# Altium schematic sheet Schematic.SchDoc(Schematic)
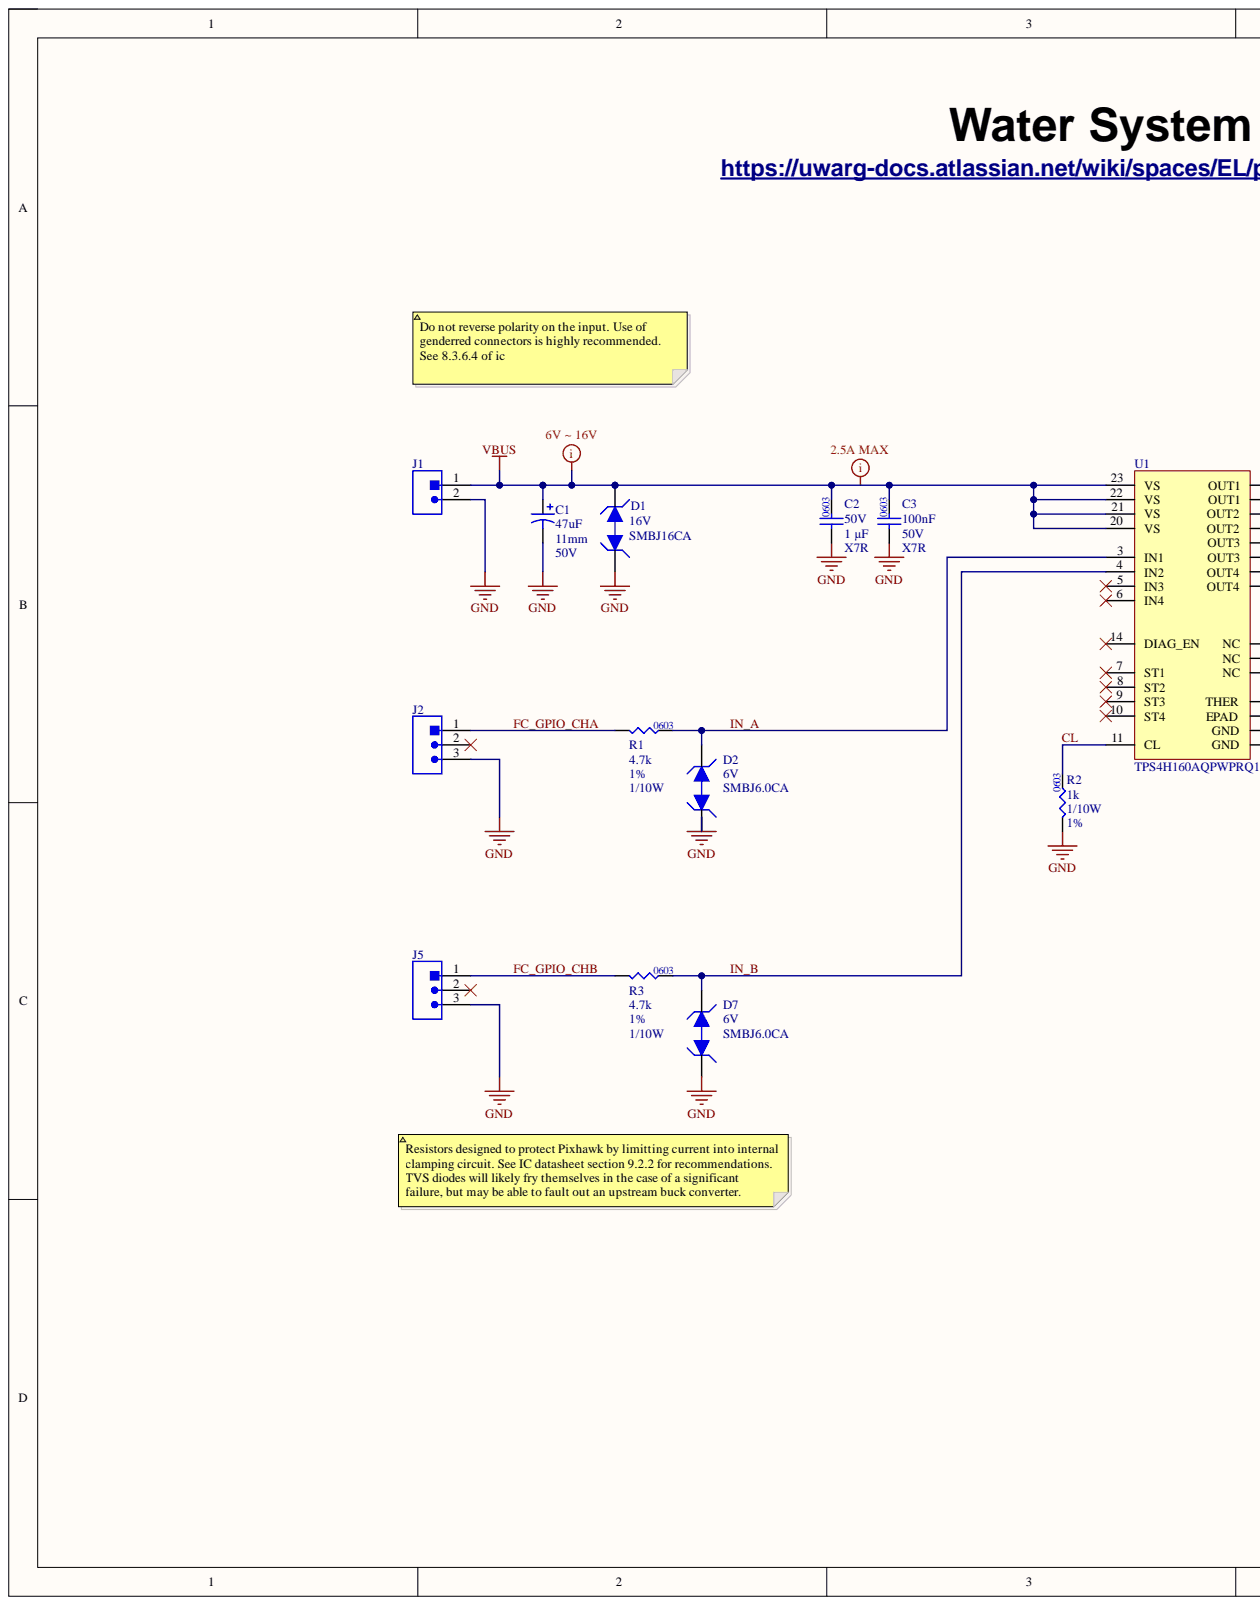
[diagram p0: Schematic.SchDoc, left]
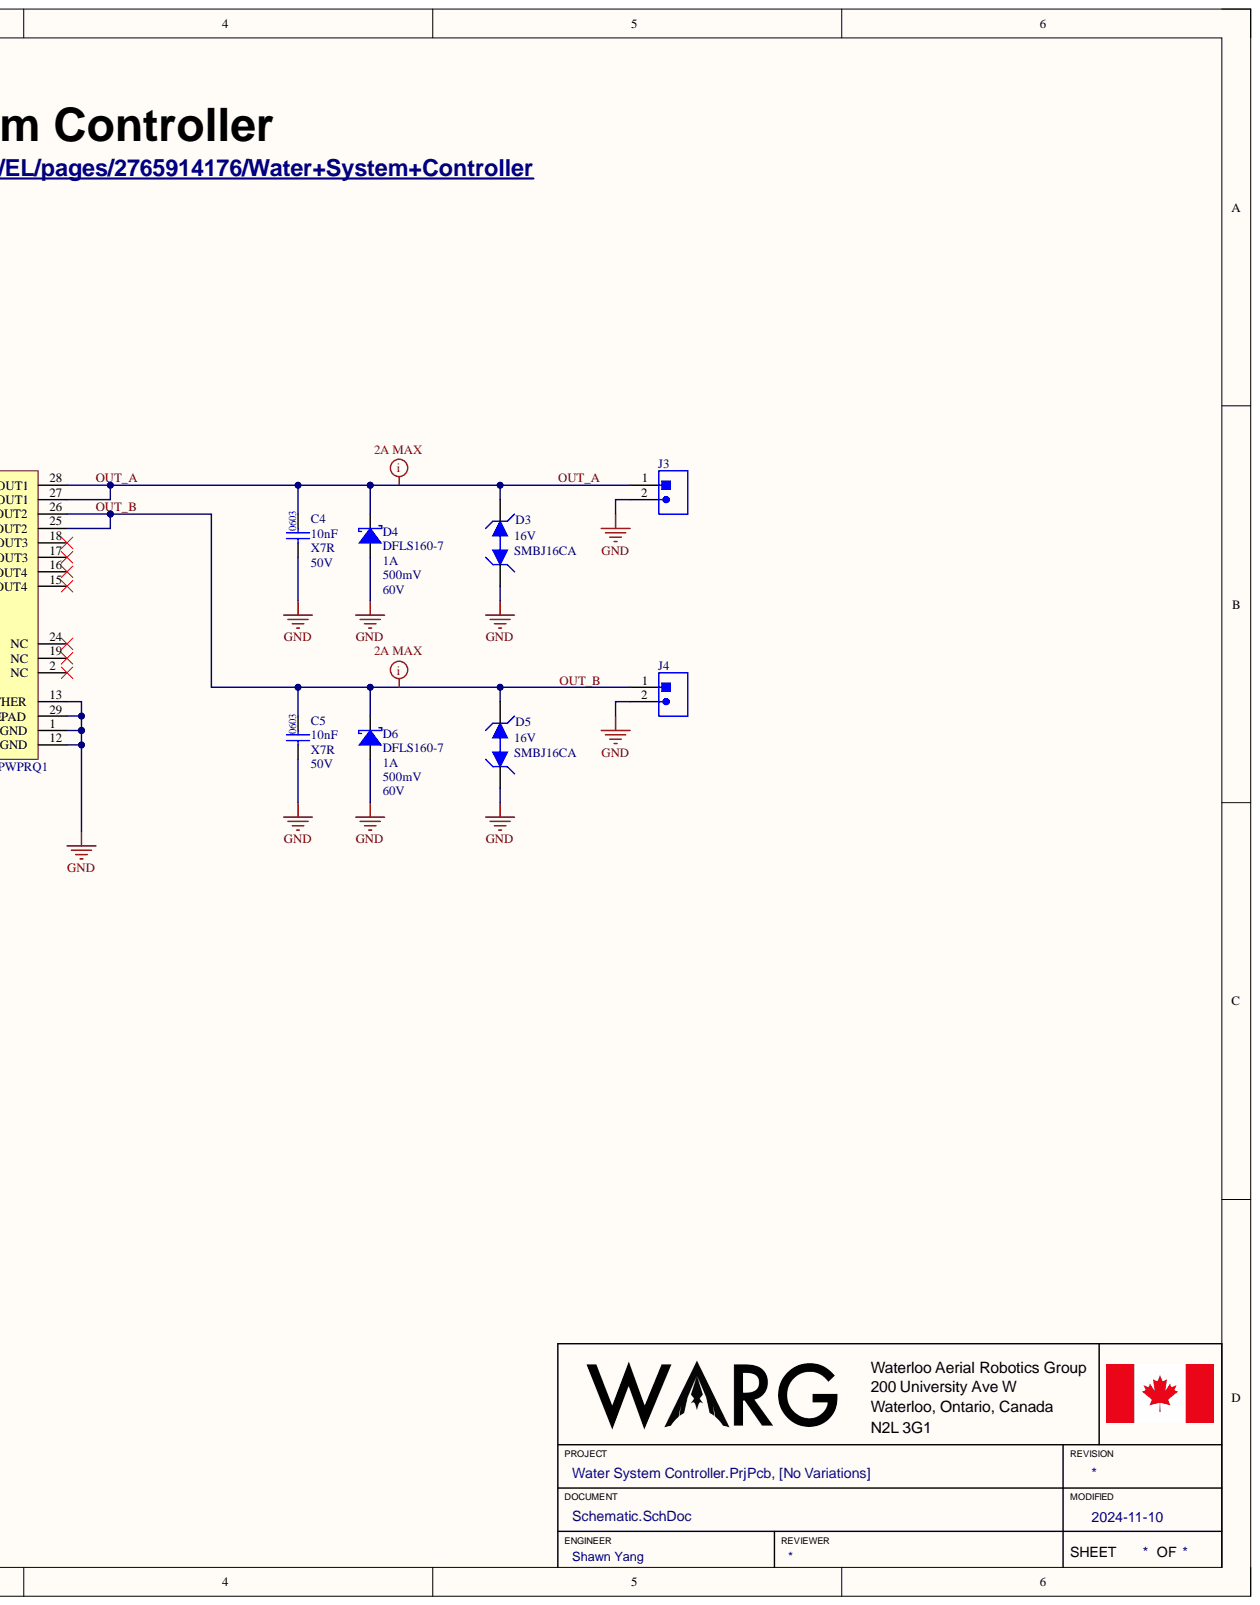
[diagram p1: Schematic.SchDoc, right]

## Parts
C1  value 47uF  Height: 11mm  Voltage: 50V  [50YXF47MEFC6.3X11-Footprint-1]  (hidden comment: 50YXF47MEFC6.3X11)
C2  Capacitance: 1 µF  Package: 0603  Rated Voltage: 50V  Temperature Coefficient: X7R  [.CAP_0603-Footprint-1]  (hidden comment: 06035C105KAT2A)
C3  value 100nF  Temperature Coefficient: X7R  Voltage Rating: 50V  [.CAP_0603-Footprint-1]  (hidden comment: CGA3E2X7R1H104K080AA)
C4  value 10nF  Case/Package: 0603  Rated DC Voltage (URdc): 50V  Temperature Characteristics Code: X7R  [.CAP_0603-Footprint-1]  (hidden comment: CC0603KRX7R9BB103)
C5  value 10nF  Case/Package: 0603  Rated DC Voltage (URdc): 50V  Temperature Characteristics Code: X7R  [.CAP_0603-Footprint-1]  (hidden comment: CC0603KRX7R9BB103)
D1  SMBJ16CA  Rep Pk Reverse Voltage-Max: 16V  [DO-214AA-Footprint-1]
D2  SMBJ6.0CA  VRWM: 6V  [DO-214AA-Footprint-1]
D3  SMBJ16CA  Rep Pk Reverse Voltage-Max: 16V  [DO-214AA-Footprint-1]
D4  DFLS160-7  Forward Voltage: 500mV  Max Output current: 1A  Min Breakdown Voltage: 60V  [DFLS160-7_Footprint_1]
D5  SMBJ16CA  Rep Pk Reverse Voltage-Max: 16V  [DO-214AA-Footprint-1]
D6  DFLS160-7  Forward Voltage: 500mV  Max Output current: 1A  Min Breakdown Voltage: 60V  [DFLS160-7_Footprint_1]
D7  SMBJ6.0CA  VRWM: 6V  [DO-214AA-Footprint-1]
J1  SOLDERPAD_2PIN  [SOLDERPAD_2PIN-Footprint]  (value not drawn)
J2  SOLDERPAD_3PIN  [SOLDERPAD_3PIN-Footprint-1]  (value not drawn)
J3  SOLDERPAD_2PIN  [SOLDERPAD_2PIN-Footprint]  (value not drawn)
J4  SOLDERPAD_2PIN  [SOLDERPAD_2PIN-Footprint]  (value not drawn)
J5  SOLDERPAD_3PIN  [SOLDERPAD_3PIN-Footprint-1]  (value not drawn)
R1  Package: 0603  Resistance: 4.7k  Tolerance: 1%  [.RES_0603-Footprint-1]  (hidden comment: RC0603FR-074K7L)
R2  value 1k  Package: 0603  Power: 1/10W  Tolerance: 1%  [.RES_0603-Footprint-1]  (hidden comment: RC0603FR-071KL)
R3  Package: 0603  Resistance: 4.7k  Tolerance: 1%  [.RES_0603-Footprint-1]  (hidden comment: RC0603FR-074K7L)
U1  TPS4H160AQPWPRQ1  [TPS4H160AQPWPRQ1-Footprint-1]

## Nets
GND: C1.2 C2.1 C3.1 C4.1 C5.1 D1.1 D2.1 D3.1 D4.1 D5.1 D6.1 D7.1 J1.2 J2.3 J3.2 J4.2 J5.3 R2.1 U1.1(GND) U1.12(GND) U1.13(THER) U1.29(EPAD)
VBUS: C1.1 C2.2 C3.2 D1.2 J1.1 U1.20(VS) U1.21(VS) U1.22(VS) U1.23(VS)
CL: R2.2 U1.11(CL)
FC_GPIO_CHA: J2.1 R1.1
FC_GPIO_CHB: J5.1 R3.1
IN_A: D2.2 R1.2 U1.3(IN1)
IN_B: D7.2 R3.2 U1.4(IN2)
OUT_A: C4.2 D3.2 D4.2 J3.1 U1.27(OUT1) U1.28(OUT1)
OUT_B: C5.2 D5.2 D6.2 J4.1 U1.25(OUT2) U1.26(OUT2)

Not connected: J2 2; J5 2; U1 2(NC) 5(IN3) 6(IN4) 7(ST1) 8(ST2) 9(ST3) 10(ST4) 14(DIAG_EN) 15(OUT4) 16(OUT4) 17(OUT3) 18(OUT3) 19(NC) 24(NC)

## Library data of the parts
C1 = CMP-00104-3: CAP ALUM 47UF 20% 50V RADIAL; Component Kind: Standard; Diameter: 6.3mm; Mounting Type: Through Hole; Package: Radial; Library Name: WARG
C2 = CMP-076-000003-3: CAP CER 1uF  ±10% 50V X7R 0603; Mfr_Name: KYOCERA AVX; Component Kind: Standard; ComponentLink1Description: Digikey; ComponentLink1URL: https://www.digikey.ca/en/products/detail/kyocera-avx/06035C105KAT2A/6564263; Design Item ID: 06035C105KAT2A; Source: 06035C105KAT2A.SchLib; Tolerance: ±10%; Library Name: WARG
C3 = CMP-00227-5: CAP CER 0.1UF 50V X7R 0603; Component Kind: Standard; Library Name: WARG
C4 C5 = CMP-00271-1: CAP CER 10000PF 50V X7R 0603; Dielectric Material: Ceramic; Component Kind: Standard; Library Name: WARG
D1 D3 D5 = CMP-00424-1: TVS DIODE 16VWM 26VC SMB; Breakdown Voltage-Nom: 19.15V; JEDEC-95 Code: DO-214AA; Max Breakdown Voltage: 19.7V; Max Power Dissipation: 5W; Min Breakdown Voltage: 17.8V; Polarity: Bidirectional; Component Kind: Standard; Library Name: WARG
D2 D7 = CMP-00397-2: TVS DIODE 6VWM 10.3VC SMB; Component Kind: Standard; Library Name: WARG
D4 D6 = CMP-00177-2: DIODE SCHOTTKY 60V 1A PWRDI123; Application(s): EFFICIENCY; Case Connection: CATHODE; Diode Element Material: SILICON; Diode Type: RECTIFIER; Max Reverse Current: 100uA; Non-rep Pk Forward Current-Max: 50A; Number of Elements: 1; Package Body Material: Plastic; Qualification Status: Not Qualified; Reference Standard: AEC-Q101; Time @ Peak Reflow Temperature-Max (s): 40s; Component Kind: Standard; JESD-30 Code: R-PDSO-F2; JESD-609 Code: e3; Max Operating Temperature: 150°C; Min Operating Temperature: -65°C; Moisture Sensitivity Level: 1; Number of Phases: 1; Package Shape: Rectangular; Package Style: SMALL OUTLINEMeter; Peak Reflow Temperature (Cel): 260°C; Pins: 2; Reach SVHC Compliant: No; Rep Pk Reverse Voltage-Max: 60V; Reverse Test Voltage: 60V; RoHS Compliant: Yes; Surface Mount: Yes; Technology: SCHOTTKY; Terminal Finish: Tin; Terminal Form: Flat; Terminal Position: DUAL; Library Name: WARG
J1 J3 J4 = CMP-00296-1: Solder Pad 2 Pins, 2.54mm pitch, 1.27mm pad size; Component Kind: Standard; Library Name: WARG
J2 J5 = CMP-00292-3: Solder Pad 3 Pin, 2.54mm pitch; Component Kind: Standard; Mount: SMD; Pins: 3; Pitch: 2.54mm; Type: Solder Pads; Library Name: WARG
R1 R3 = CMP-00344-1: RES 4.7K OHM 1% 1/10W 0603; Component Kind: Standard; Power: 1/10W; Library Name: WARG
R2 = CMP-009-00012-4: RES 1k OHM 1% 1/10W 0603; Component Kind: Standard; Library Name: WARG
U1 = CMP-00273-2-5: IC PWR SWTCH N-CHAN 1:1 28HTSSOP; Component Kind: Standard; Library Name: WARG
